# Altium schematic sheet Current_Sink.SchDoc(Current_Sink)
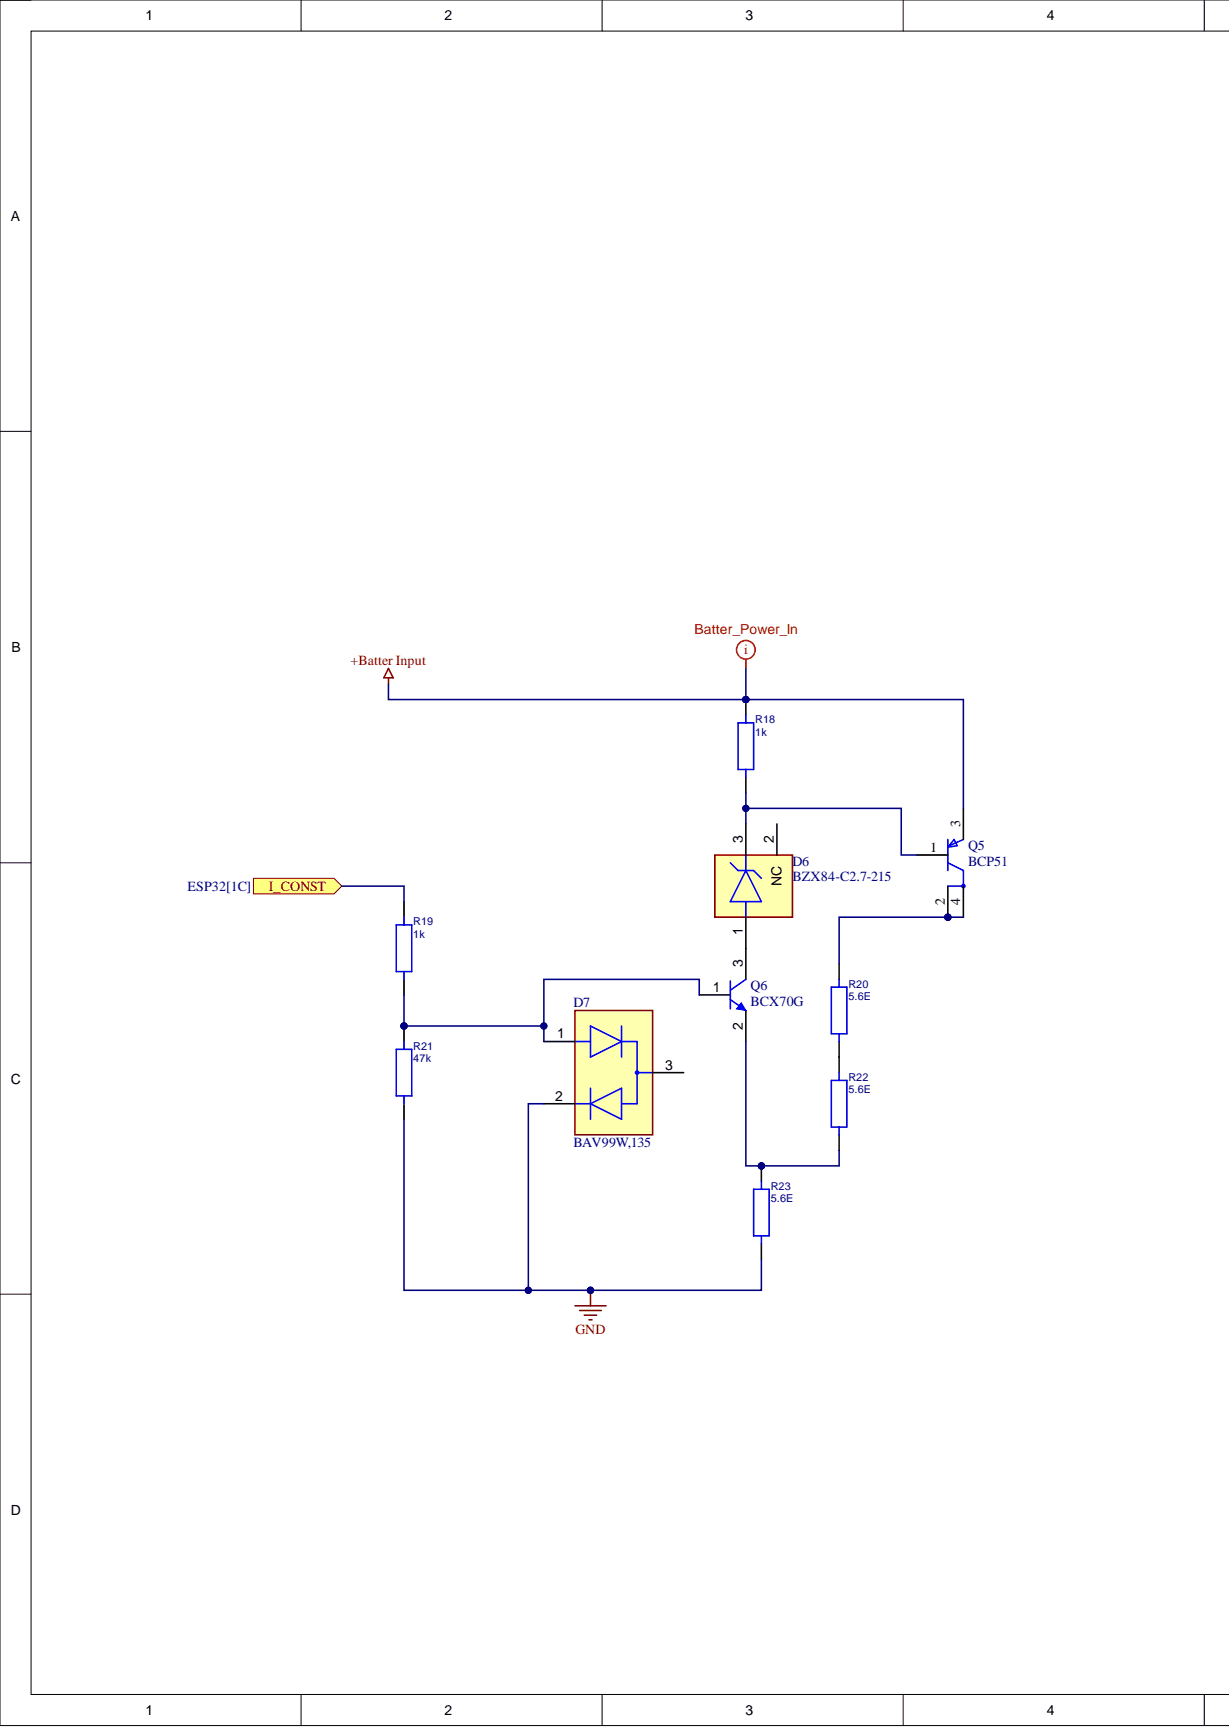
[diagram p0: Current_Sink.SchDoc, left]
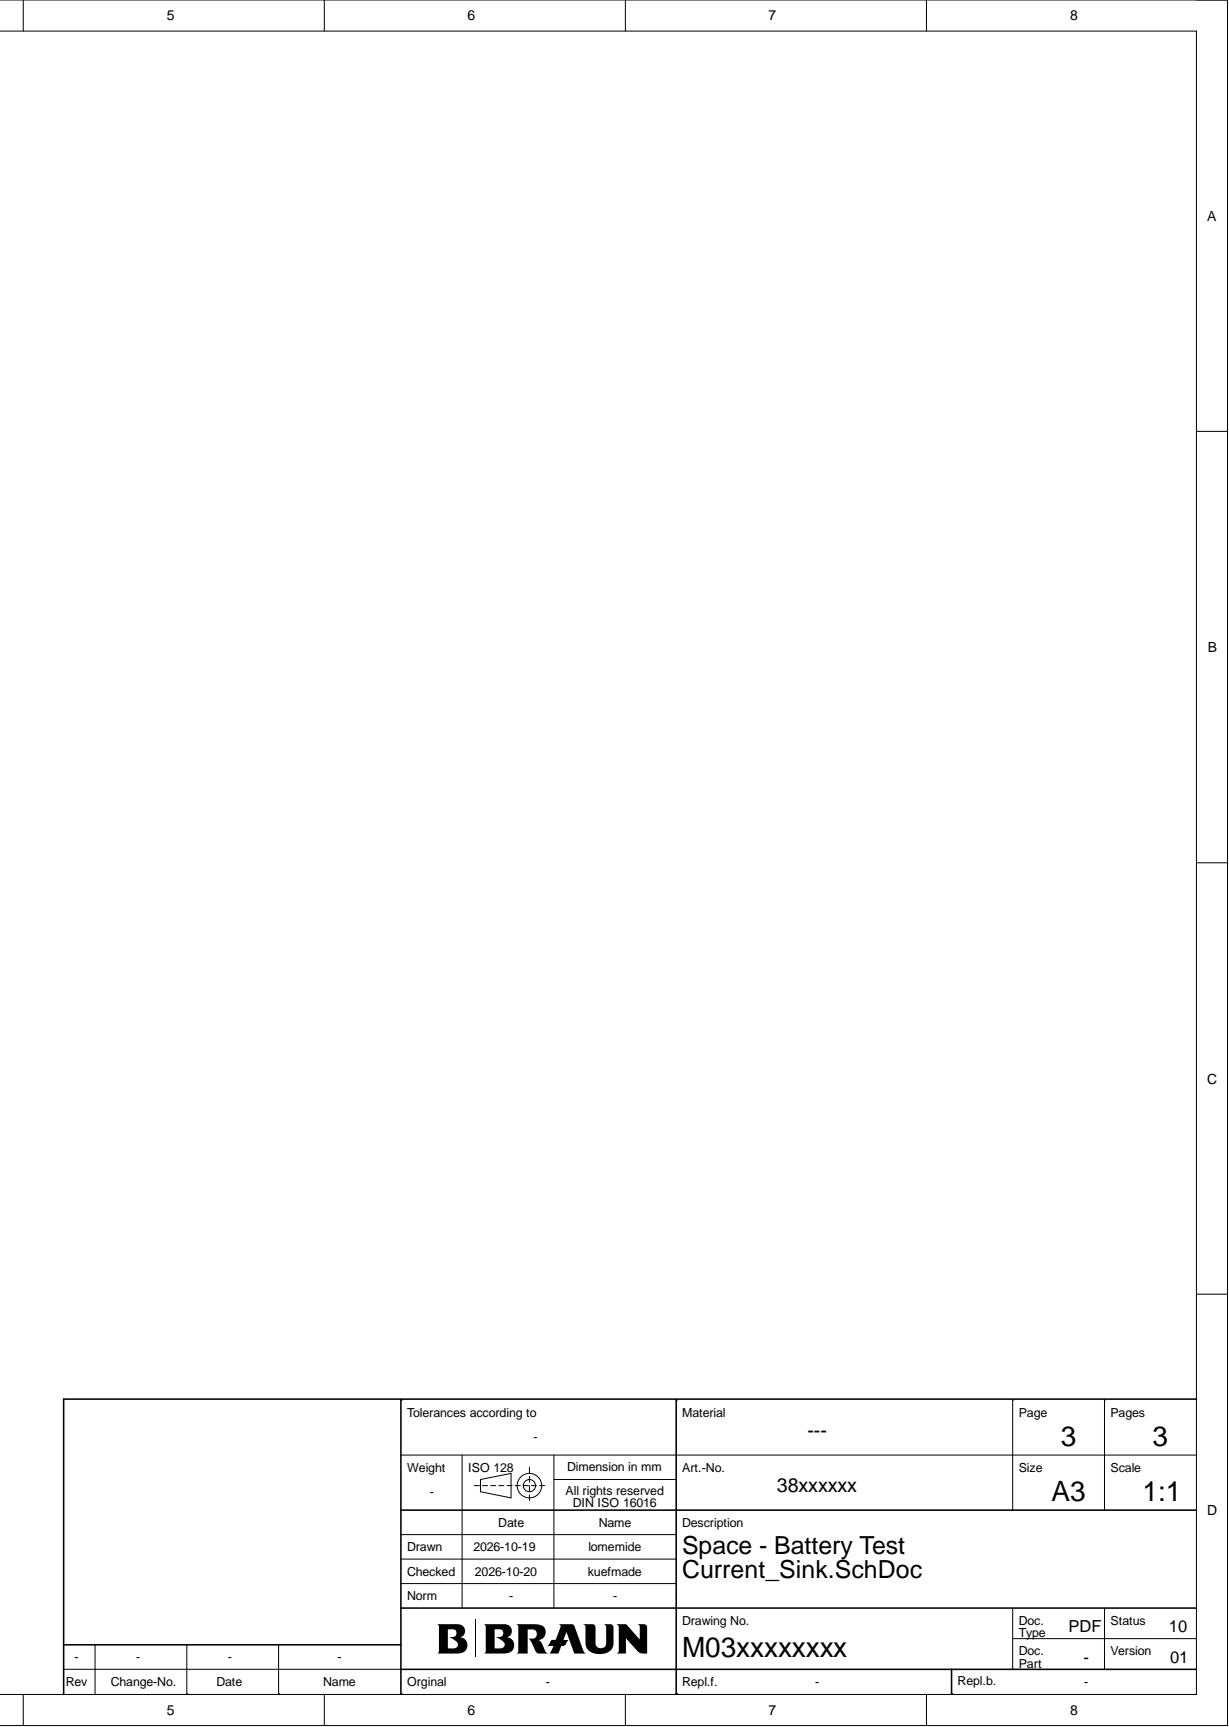
[diagram p1: Current_Sink.SchDoc, right]

## Parts
D6  BZX84-C2.7-215  [FP-SOT23-MFG]
D7  BAV99W,135  [FP-SOT323-IPC_B]
Q5  BCP51  [FP-318H-MFG]
Q6  BCX70G  [INF-SOT23_N]
R18  1k  [RES0805MD]
R19  1k  [RES0805MD]
R20  5.6E  [RES0805MD]
R21  47k  [RES0805MD]
R22  5.6E  [RES0805MD]
R23  5.6E  [RES0805MD]

## Ports
I_CONST

## Nets
+Batter Input: Q5.3 R18.2
GND: D7.2 R21.1 R23.1
I_CONST: R19.2 ; port I_CONST
NetD6_1: D6.1 Q6.3
NetD6_3: D6.3 Q5.1 R18.1
NetD7_1: D7.1 Q6.1 R19.1 R21.2
NetQ5_2: Q5.2 Q5.4 R20.2
NetQ6_2: Q6.2 R22.1 R23.2
NetR20_1: R20.1 R22.2

Not connected: D6 2; D7 3

## Library data of the parts
D6 = CMP-14400-000001-1: DIODE ZENER 2,7V 250MW SOT23; Manufacturer: Nexperia; Manufacturer Part Number: BZX84-C20,215; Automotive Grade: Grade 0; Forward Current: 200mA; Max Junction Temp: +150°C; Max Reverse Leakage Current: 0.05uA; Max Zener Voltage: 21.2V; Nom Zener Voltage: 20V; Reverse Voltage: 14V; Zener Impedance: 15Ω; Ambient Temperature Range High: +150°C; Automotive: Yes; Category: Diode; Component Kind: Standard; ComponentLink2Description: Datasheet; ComponentLink2URL: https://assets.nexperia.com/documents/data-sheet/BZX84_SER.pdf; Device Class L1: Discrete Semiconductors; Device Class L2: Diodes; Device Class L3: Zener Diodes; Digikey Description: DIODE ZENER 20V 250MW SOT23; Footprint Url: https://assets.nexperia.com/documents/outline-drawing/SOT23.pdf; Height: 1.1mm; Ipc Land Pattern Name: SOT95P230X100-3; Lead Free: Yes; Library Name: Altium Content Vault; Mouser Description: Diode Zener Single 20V 5% 250mW Automotive 3-Pin SOT-23 T/R; Octopart: https://octopart.com/bzx84-c20%2C215-nexperia-78740231; Package: SOT23; Power Dissipation: 250mW; RoHS: TRUE; Temperature: -65°C to +150°C; Temperature Range High: +150°C; Temperature Range Low: -65°C
D7 = CMP-14399-000011-1: DIODE ARRAY GP 100V 150MA SOT323; Component Kind: Standard; Library Name: Altium Content Vault
Q5 = CMP-00278-84324633-1: BCP51 Trans Gp Bjt PNP 45V 1.5A 1000MW 4-PIN(3+TAB) SOT-223 T/r / Trans PNP 45V 1.5A SOT-223; Manufacturer Lifecycle Status: OBSOLETE; Collector Base Voltage (VCBO): -45V; Collector Emitter Saturation Voltage: -500mV; Emitter Base Voltage (VEBO): -5V; hFE Min: 40; Max Collector Current: -1.5A; Max Junction Temperature (Tj): 150°C; Number of Elements: 1; Power Dissipation: 1W; Case/Package: SOT-223; Collector Emitter Breakdown Voltage: -45V; Collector Emitter Voltage (VCEO): -45V; Component Kind: Standard; Height: 1.8mm; Lead Free: Lead Free; Library Name: Altium Content Vault; Lifecycle Status: Obsolete; Max Operating Temperature: 150°C; Min Operating Temperature: -55°C; NexarPartId: 84324633; Number of Pins: 3; Polarity: PNP; RoHS: Compliant; Schedule B: 8541290080, 8541290080
Q6 = CMP-1177-00052-1: NPN Silicon AF Transistor, 45 V VCEO, 100 mA IC, SOT23, Reel, Green; Manufacturer: Infineon; F (typ): 2 dB; fT (typ): 250Â MHz; Green: TRUE; IBM (max): 200Â mA; IC (max): 100Â mA; ICBO (max): 20Â nA; Ptot (max): 330Â mW; VCBO (max): 45Â V; VEBO (max): 6Â V; Component Kind: Standard; ComponentLink1Description: Manufacturer URL; ComponentLink1URL: http://www.infineon.com/; ComponentLink2Description: Datasheet; ComponentLink2URL: http://www.infineon.com/dgdl/bcw60_bcx70.pdf?folderId=db3a304314dca389011541d30fa21656&fileId=db3a304314dca38901154219c9141726; ComponentLink3Description: Package Specification; ComponentLink3URL: http://www.infineon.com/dgdl/SOT23-Package_Overview.pdf?folderId=db3a30431936bc4b0119532290053c66&fileId=db3a30431936bc4b0119533468123c85; ICM (max): 200Â mA; Library Name: Altium Content Vault; Mounting Technology: Surface Mount; PackageDescription: 3-Pin Small Outline Transistor Package, 1.3 x 2.9 mm Body, 0.95 mm Pitch; PackageReference: SOT23; PackageVersion: Apr-08; Packing: Reel; PartNumber: BCX70G; Polarity: NPN; VCEO (max): 45Â V
R18-R23 = UID-0F2DF2513351442B7CD8: RES 2K2 1% 125mW 0805; Manufacturer: Yageo; Manufacturer Part Number: RC0805FR-132K2L; SAP-Partnumber: 35110490; Compliance: RoHS; Database Table Name: All; Library Status: New; Remark: NGX; Reviewer: hoersede; Temperature - Rated: -55 °C to +155 °C; Temperature Coefficient: TC100; Voltage - Rated: 150V; Component Kind: Standard; ComponentLink1Description: Datasheet; ComponentLink1URL: https://code.bbraun.io/BA-RD-EE/altium-partlib/raw/main/components/datasheets/35110490_SMD_Widerstand_2K2_1Proz_0805/Yageo_RC0805FR-132K2L.pdf; ComponentLink2Description: Compliance Certificate; ComponentLink2URL: https://code.bbraun.io/BA-RD-EE/altium-partlib/raw/main/components/datasheets/35110490_SMD_Widerstand_2K2_1Proz_0805/Yageo_RC0805FR-132K2L.pdf; ComponentLink3Description: Datasheet Folder; ComponentLink3URL: https://code.bbraun.io/BA-RD-EE/altium-partlib/raw/main/components/datasheets/35110490_SMD_Widerstand_2K2_1Proz_0805/; ComponentLink4Description: Data Sheet Library; ComponentLink4URL: http://ais.development.bbraun.com/dsl/35110490; Creation Date: 22.04.2022; Last Updated: 22.06.2023; Lifecycle Status: Active; Maximum Height: 0.6 mm; Package / Case: 0805; partid: 156; Power - Rated: 125mW; Price@1k: 0,0075 €; Review Date: 10.03.2026; Supplier 1: Mouser; Supplier 2: Digikey; Supplier 3: RS Components; Supplier 4: Farnell; Supplier Part Number 1: 603-RC0805FR-132K2L; Supplier Part Number 2: 13-RC0805FR-132K2LTR-ND; Technology: SMT; Tolerance: 1%; Type: Thick Film; uid: UID-0F2DF2513351442B7CD8; Value: 2k2; Creator: GEG DF,GK; Library Name: BBM-partlib-official.DbLib
